# Altium schematic sheet 2126_1_MatrixBoard_AffichageMatricielNomEtudiant.SchDoc(2126_1_MatrixBoard_AffichageMatricielNomEtudiant)
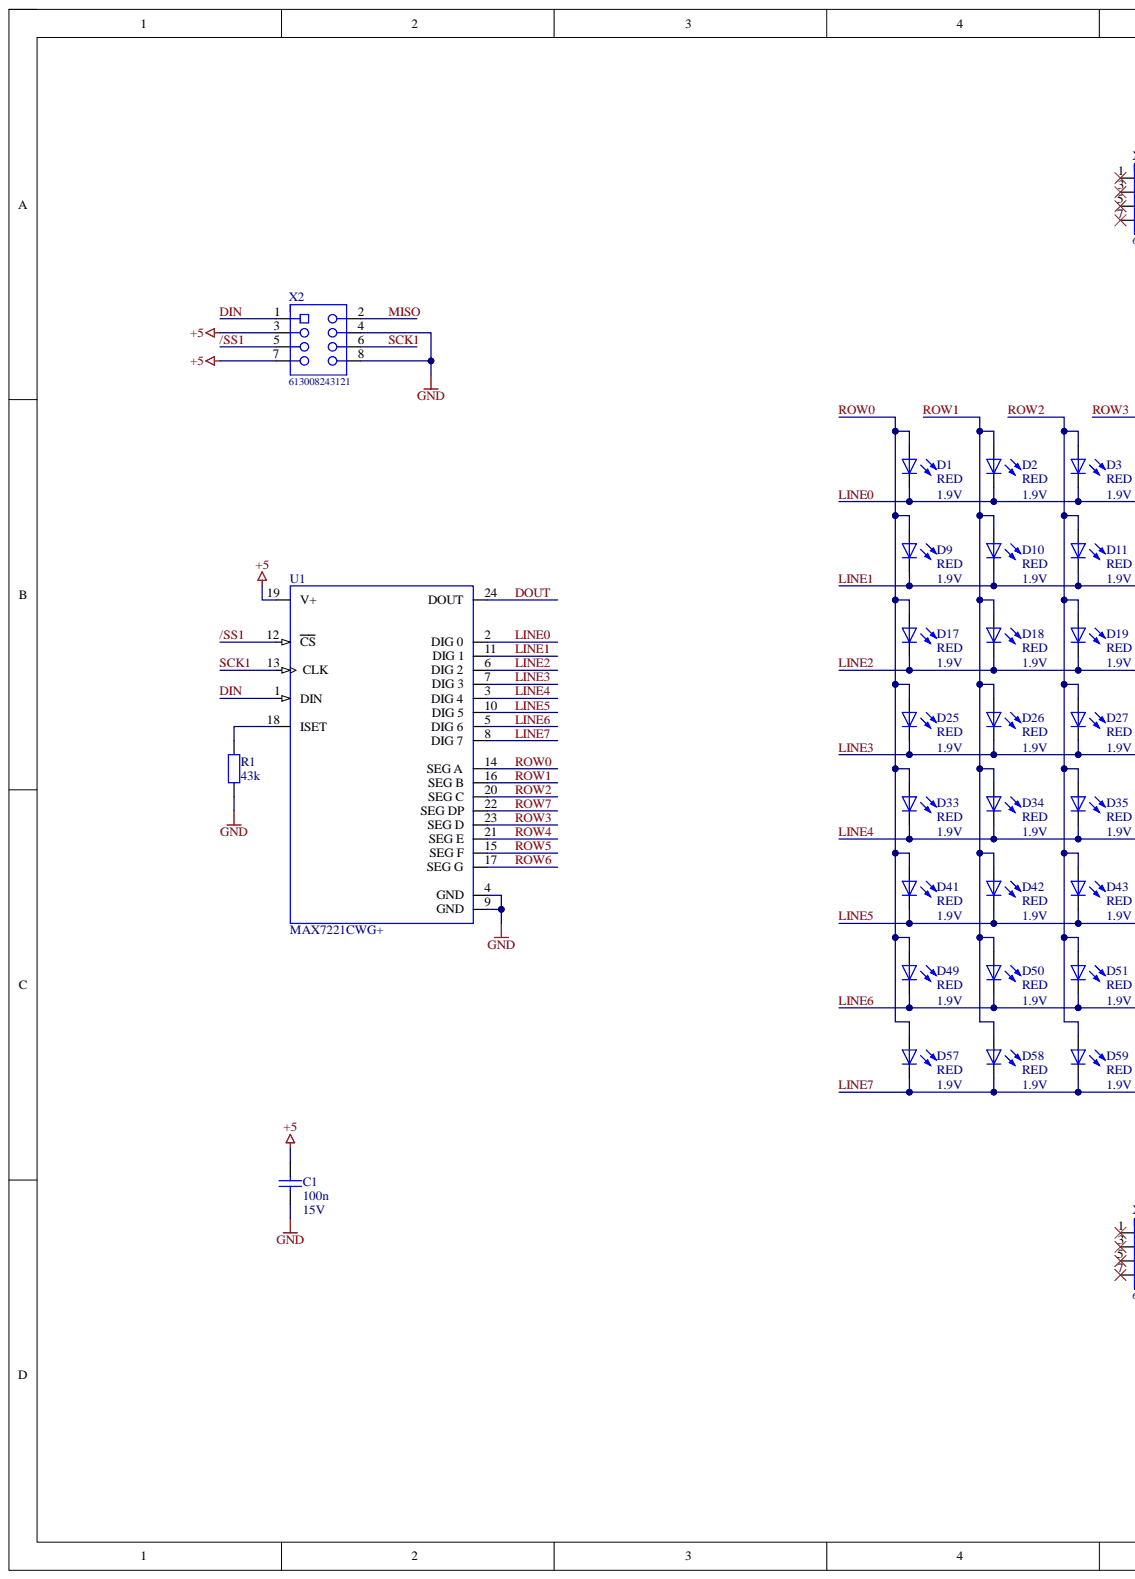
[diagram p0: 2126_1_MatrixBoard_AffichageMatricielNomEtudiant.SchDoc, left]
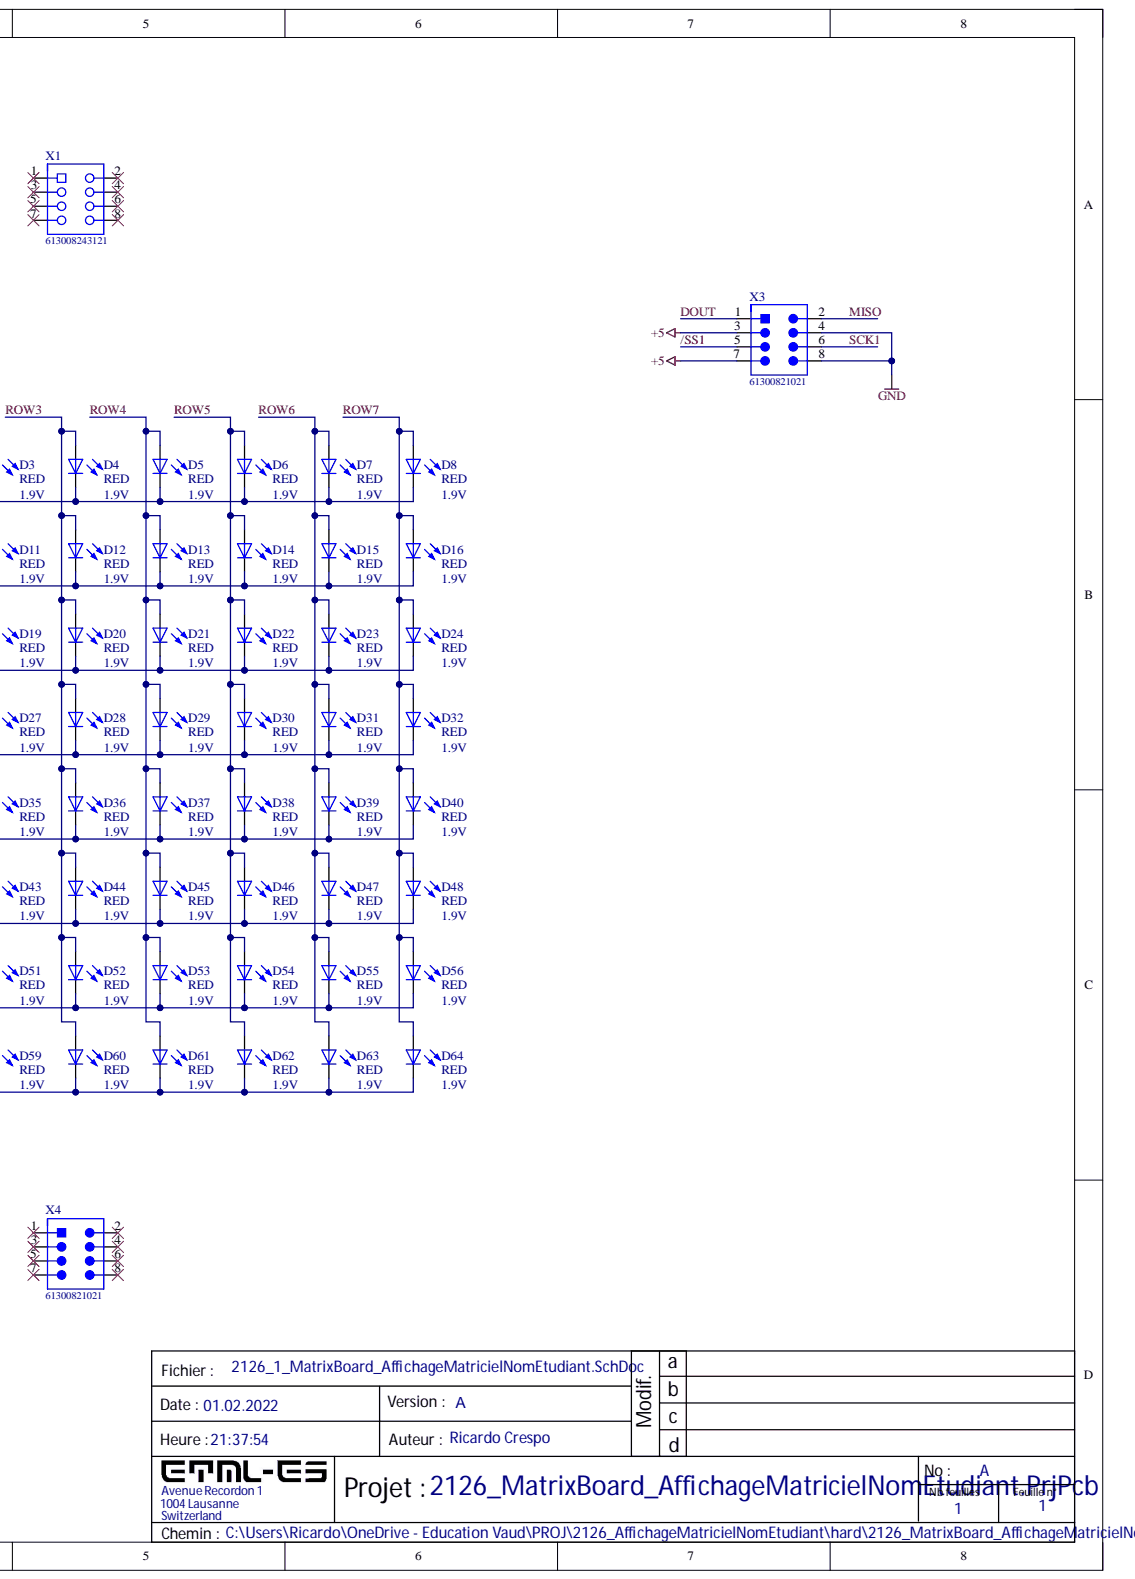
[diagram p1: 2126_1_MatrixBoard_AffichageMatricielNomEtudiant.SchDoc, right]

## Parts
C1  100n  [CAPC2013X145X48NL20T23]
D1  RED  [LEDRD254W57D500H1070]
D2  RED  [LEDRD254W57D500H1070]
D3  RED  [LEDRD254W57D500H1070]
D4  RED  [LEDRD254W57D500H1070]
D5  RED  [LEDRD254W57D500H1070]
D6  RED  [LEDRD254W57D500H1070]
D7  RED  [LEDRD254W57D500H1070]
D8  RED  [LEDRD254W57D500H1070]
D9  RED  [LEDRD254W57D500H1070]
D10  RED  [LEDRD254W57D500H1070]
D11  RED  [LEDRD254W57D500H1070]
D12  RED  [LEDRD254W57D500H1070]
D13  RED  [LEDRD254W57D500H1070]
D14  RED  [LEDRD254W57D500H1070]
D15  RED  [LEDRD254W57D500H1070]
D16  RED  [LEDRD254W57D500H1070]
D17  RED  [LEDRD254W57D500H1070]
D18  RED  [LEDRD254W57D500H1070]
D19  RED  [LEDRD254W57D500H1070]
D20  RED  [LEDRD254W57D500H1070]
D21  RED  [LEDRD254W57D500H1070]
D22  RED  [LEDRD254W57D500H1070]
D23  RED  [LEDRD254W57D500H1070]
D24  RED  [LEDRD254W57D500H1070]
D25  RED  [LEDRD254W57D500H1070]
D26  RED  [LEDRD254W57D500H1070]
D27  RED  [LEDRD254W57D500H1070]
D28  RED  [LEDRD254W57D500H1070]
D29  RED  [LEDRD254W57D500H1070]
D30  RED  [LEDRD254W57D500H1070]
D31  RED  [LEDRD254W57D500H1070]
D32  RED  [LEDRD254W57D500H1070]
D33  RED  [LEDRD254W57D500H1070]
D34  RED  [LEDRD254W57D500H1070]
D35  RED  [LEDRD254W57D500H1070]
D36  RED  [LEDRD254W57D500H1070]
D37  RED  [LEDRD254W57D500H1070]
D38  RED  [LEDRD254W57D500H1070]
D39  RED  [LEDRD254W57D500H1070]
D40  RED  [LEDRD254W57D500H1070]
D41  RED  [LEDRD254W57D500H1070]
D42  RED  [LEDRD254W57D500H1070]
D43  RED  [LEDRD254W57D500H1070]
D44  RED  [LEDRD254W57D500H1070]
D45  RED  [LEDRD254W57D500H1070]
D46  RED  [LEDRD254W57D500H1070]
D47  RED  [LEDRD254W57D500H1070]
D48  RED  [LEDRD254W57D500H1070]
D49  RED  [LEDRD254W57D500H1070]
D50  RED  [LEDRD254W57D500H1070]
D51  RED  [LEDRD254W57D500H1070]
D52  RED  [LEDRD254W57D500H1070]
D53  RED  [LEDRD254W57D500H1070]
D54  RED  [LEDRD254W57D500H1070]
D55  RED  [LEDRD254W57D500H1070]
D56  RED  [LEDRD254W57D500H1070]
D57  RED  [LEDRD254W57D500H1070]
D58  RED  [LEDRD254W57D500H1070]
D59  RED  [LEDRD254W57D500H1070]
D60  RED  [LEDRD254W57D500H1070]
D61  RED  [LEDRD254W57D500H1070]
D62  RED  [LEDRD254W57D500H1070]
D63  RED  [LEDRD254W57D500H1070]
D64  RED  [LEDRD254W57D500H1070]
R1  43k  [RESC2013X70X40ML20T20]
U1  MAX7221CWG+  [FP-W24-1-IPC_B]
X1  613008243121  [613008243121]
X2  613008243121  [613008243121]
X3  61300821021  [61300821021]
X4  61300821021  [61300821021]

## Nets
+5: C1.2 U1.19(V+) X2.3 X2.7 X3.3 X3.7
GND: C1.1 R1.1 U1.4(GND) U1.9(GND) X2.4 X2.8 X3.4 X3.8
/SS1: U1.12(CS) X2.5 X3.5
DIN: U1.1(DIN) X2.1
DOUT: U1.24(DOUT) X3.1
LINE0: D1.2 D2.2 D3.2 D4.2 D5.2 D6.2 D7.2 D8.2 U1.2(DIG_0)
LINE1: D9.2 D10.2 D11.2 D12.2 D13.2 D14.2 D15.2 D16.2 U1.11(DIG_1)
LINE2: D17.2 D18.2 D19.2 D20.2 D21.2 D22.2 D23.2 D24.2 U1.6(DIG_2)
LINE3: D25.2 D26.2 D27.2 D28.2 D29.2 D30.2 D31.2 D32.2 U1.7(DIG_3)
LINE4: D33.2 D34.2 D35.2 D36.2 D37.2 D38.2 D39.2 D40.2 U1.3(DIG_4)
LINE5: D41.2 D42.2 D43.2 D44.2 D45.2 D46.2 D47.2 D48.2 U1.10(DIG_5)
LINE6: D49.2 D50.2 D51.2 D52.2 D53.2 D54.2 D55.2 D56.2 U1.5(DIG_6)
LINE7: D57.2 D58.2 D59.2 D60.2 D61.2 D62.2 D63.2 D64.2 U1.8(DIG_7)
MISO: X2.2 X3.2
ROW0: D1.1 D9.1 D17.1 D25.1 D33.1 D41.1 D49.1 D57.1 U1.14(SEG_A)
ROW1: D2.1 D10.1 D18.1 D26.1 D34.1 D42.1 D50.1 D58.1 U1.16(SEG_B)
ROW2: D3.1 D11.1 D19.1 D27.1 D35.1 D43.1 D51.1 D59.1 U1.20(SEG_C)
ROW3: D4.1 D12.1 D20.1 D28.1 D36.1 D44.1 D52.1 D60.1 U1.23(SEG_D)
ROW4: D5.1 D13.1 D21.1 D29.1 D37.1 D45.1 D53.1 D61.1 U1.21(SEG_E)
ROW5: D6.1 D14.1 D22.1 D30.1 D38.1 D46.1 D54.1 D62.1 U1.15(SEG_F)
ROW6: D7.1 D15.1 D23.1 D31.1 D39.1 D47.1 D55.1 D63.1 U1.17(SEG_G)
ROW7: D8.1 D16.1 D24.1 D32.1 D40.1 D48.1 D56.1 D64.1 U1.22(SEG_DP)
SCK1: U1.13(CLK) X2.6 X3.6
NetR1_2: R1.2 U1.18(ISET)

Not connected: X1 1 2 3 4 5 6 7 8; X4 1 2 3 4 5 6 7 8

## Library data of the parts
C1 = CMP-2007-03167-1: VJ0805Y104KNAAT; Manufacturer: Vitramon; Manufacturer 1: Vishay Vitramon; Component Kind: Standard; Manufacturer Part Number 1: VJ0805Y104KNAAT; Part Number: VJ0805Y104KNAAT; Library Name: 2126_AffichageMatriciel.SchLib
D1-D64 = 151031VS04000: WL-TMRC THT Mono-color Round Color, size 3mm, Bright Green, 2.1V, 40deg; Chip Technology: AlInGaP; Dominant Wavelength: 570nm; Luminous Intensity: 120mcd; Peak Wavelength: 573nm; Component Kind: Standard; ComponentLink1Description: Manufacturer; ComponentLink1URL: http://www.we-online.de; ComponentLink2Description: Datasheet; ComponentLink2URL: https://katalog.we-online.de/led/datasheet/151031VS04000.pdf; Emitting Color: Bright Green; Forward Voltage: 2.1V; Mounting Technology: THT; Operating Temperature: -40 to +85degC; Packaging: Bulk; Part Number: 151031VS04000; Published Date: January 2021; Size: 3mm; Viewing Angle: 40deg; Library Name: 2126_AffichageMatriciel.SchLib; Publisher: Wurth Elektronik
R1 = CMP-1013-00623-1: 10K 0.125W 1% 0805 (2012 Metric)  SMD; Manufacturer 1: Vishay Dale; Manufacturer 10: Panasonic; Manufacturer Part Number 1: CRCW080510K0FKEC; Manufacturer Part Number 10: ERJ-6ENF1002V; Case-EIA: 0805; Case-Metric: 2012; Component Kind: Standard; Manufacturer 2: Yageo; Manufacturer 3: Vishay Dale; Manufacturer 4: Vishay Dale; Manufacturer 5: Bourns; Manufacturer 6: Rohm; Manufacturer 7: Vishay; Manufacturer 8: Vishay Dale; Manufacturer 9: Vishay Dale; Manufacturer Part Number 2: RC0805FR-0710KL; Manufacturer Part Number 3: CRCW080510K0FKTC; Manufacturer Part Number 4: CRCW080510K0FKEB; Manufacturer Part Number 5: CR0805-FX-1002ELF; Manufacturer Part Number 6: MCR10EZPF1002; Manufacturer Part Number 7: CRCW080510K0FKEA; Manufacturer Part Number 8: CRCW080510K0FKTB; Manufacturer Part Number 9: CRCW080510K0FKTA; Power: 0.125 W; Supplier 1: Digi-Key; Supplier Part Number 1: 541-10.0KCCT-ND; Technology: SMT; Tolerance: 1 %; Value: 10k; Library Name: 2126_AffichageMatriciel.SchLib
U1 = CMP-05718-000002-1: IC DRVR 7 SEGMNT 8 DIGIT 24SOIC; Manufacturer: Maxim Integrated; Max Junction Temp: +150°C; Max Power Dissipation: 941mW; Max Supply Voltage: 5.5V; Min Supply Voltage: 4V; Nominal Supply Current: 330mA; Number Of Outputs: 8; Standoff Height: 0.1mm; Automotive: No; Category: IC; Component Kind: Standard; ComponentLink2Description: Datasheet; ComponentLink2URL: https://datasheets.maximintegrated.com/en/ds/MAX7219-MAX7221.pdf; Device Class L1: Integrated Circuits (ICs); Device Class L2: Power Management ICs; Device Class L3: LED Drivers; Digikey Description: IC DRVR 7 SEGMNT 8 DIGIT 24SOIC; Footprint Url: https://pdfserv.maximintegrated.com/land_patterns/90-0182.PDF; Height: 2.65mm; Ipc Land Pattern Name: SOIC127P1032X250-24; Lead Free: Yes; Manufacturer Part Number: MAX7221CWG+; Mouser Description: In a Tube of 30, Maxim MAX7221CWG+, LED Driver, 8-Digits 64-Segments, 5 V, 24-Pin SOIC W; Octopart: https://octopart.com/max7221cwg%2B-maxim+integrated-39581478; Package: W24-1; RoHS: TRUE; Temperature: 0°C to +70°C; Temperature Range High: +70°C; Temperature Range Low: 0°C; Library Name: 2126_AffichageMatriciel.SchLib
X1 X2 = 613008243121: WR-PHD Socket Header, THT, pitch 2.54mm, Dual Row, Angled, 8p; Manufacturer: Wurth Elektronik; Contact Resistance: 20mOhm; Pin Number: 8; Rated Current: 3A; Withstanding Voltage: 500VAC; Working Voltage: 250VAC; Component Kind: Standard; ComponentLink1Description: Manufacturer; ComponentLink1URL: http://www.we-online.de; ComponentLink2Description: Datasheet; ComponentLink2URL: https://www.we-online.com/catalog/datasheet/613008243121.pdf; Gender: Socket Header; Manufacturer Part Number: 613008243121; Mounting Technology: THT; Operating Temperature: -40 to +125degC; Orientation: Angled; Packaging: Tray; Pitch: 2.54mm; Row Number: Dual; Library Name: 2126_AffichageMatriciel.SchLib
X3 X4 = 61300821021: WR-PHD Pin Header, THT, pitch 2.54mm, Dual Row, Angled, 8p; Manufacturer: Wurth Elektronik; Contact Resistance: 20mOhm; Pin Number: 8; Rated Current: 3A; Withstanding Voltage: 500VAC; Working Voltage: 250VAC; Component Kind: Standard; ComponentLink1Description: Manufacturer; ComponentLink1URL: http://www.we-online.de; ComponentLink2Description: Datasheet; ComponentLink2URL: https://www.we-online.com/catalog/datasheet/61300821021.pdf; Gender: Pin Header; Manufacturer Part Number: 61300821021; Mounting Technology: THT; Operating Temperature: -40 to +105degC; Orientation: Angled; Packaging: Bag; Pitch: 2.54mm; Row Number: Dual; Library Name: 2126_AffichageMatriciel.SchLib
